# Altium schematic sheet top_level.SchDoc
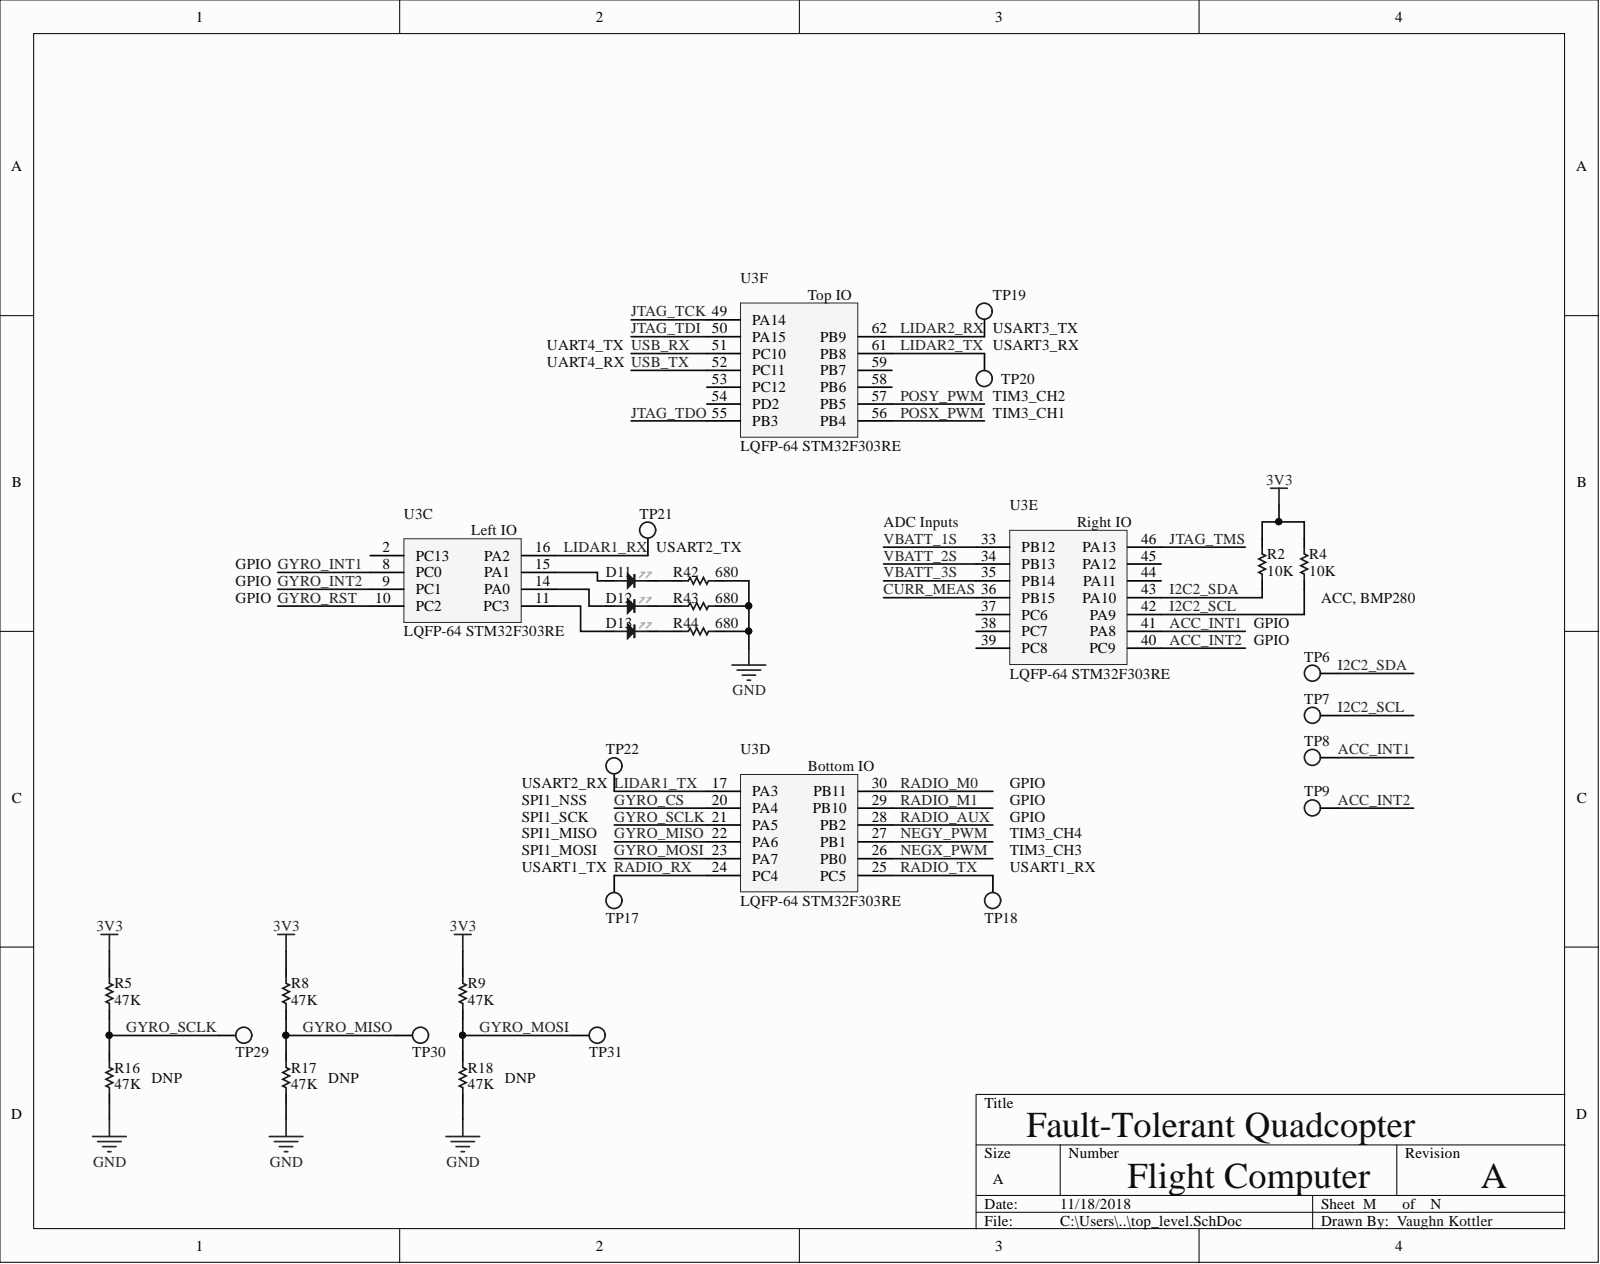
[diagram p0: top_level.SchDoc]

## Parts
D11  LED-WHT-20mA  [LED-0805]  (value not drawn)
D12  LED-WHT-20mA  [LED-0805]  (value not drawn)
D13  LED-WHT-20mA  [LED-0805]  (value not drawn)
R2  10K  [RES-0805]
R4  10K  [RES-0805]
R5  47K  [RES-0805]
R8  47K  [RES-0805]
R9  47K  [RES-0805]
R16  47K  [RES-0805]
R17  47K  [RES-0805]
R18  47K  [RES-0805]
R42  680  [RES-0805]
R43  680  [RES-0805]
R44  680  [RES-0805]
TP6  Test Point  [0603 Test Point]  (value not drawn)
TP7  Test Point  [0603 Test Point]  (value not drawn)
TP8  Test Point  [0603 Test Point]  (value not drawn)
TP9  Test Point  [0603 Test Point]  (value not drawn)
TP17  Test Point  [0603 Test Point]  (value not drawn)
TP18  Test Point  [0603 Test Point]  (value not drawn)
TP19  Test Point  [0603 Test Point]  (value not drawn)
TP20  Test Point  [0603 Test Point]  (value not drawn)
TP21  Test Point  [0603 Test Point]  (value not drawn)
TP22  Test Point  [0603 Test Point]  (value not drawn)
TP29  Test Point  [0603 Test Point]  (value not drawn)
TP30  Test Point  [0603 Test Point]  (value not drawn)
TP31  Test Point  [0603 Test Point]  (value not drawn)
U3  LQFP-64 STM32F303RE  [LQFP64]  (parts C,D,E,F)

## Nets
3V3: R2.2 R4.2 R5.2 R8.2 R9.2
GND: R16.1 R17.1 R18.1 R42.2 R43.2 R44.2
ACC_INT1: TP8.1 U3.41(PA8)
ACC_INT2: TP9.1 U3.40(PC9)
CURR_MEAS: U3.36(PB15)
GYRO_CS: U3.20(PA4)
GYRO_INT1: U3.8(PC0)
GYRO_INT2: U3.9(PC1)
GYRO_MISO: R8.1 R17.2 TP30.1 U3.22(PA6)
GYRO_MOSI: R9.1 R18.2 TP31.1 U3.23(PA7)
GYRO_RST: U3.10(PC2)
GYRO_SCLK: R5.1 R16.2 TP29.1 U3.21(PA5)
I2C2_SCL: R4.1 TP7.1 U3.42(PA9)
I2C2_SDA: R2.1 TP6.1 U3.43(PA10)
JTAG_TCK: U3.49(PA14)
JTAG_TDI: U3.50(PA15)
JTAG_TDO: U3.55(PB3)
JTAG_TMS: U3.46(PA13)
LIDAR1_RX: TP21.1 U3.16(PA2)
LIDAR1_TX: TP22.1 U3.17(PA3)
LIDAR2_RX: TP19.1 U3.62(PB9)
LIDAR2_TX: TP20.1 U3.61(PB8)
NEGX_PWM: U3.26(PB0)
NEGY_PWM: U3.27(PB1)
POSX_PWM: U3.56(PB4)
POSY_PWM: U3.57(PB5)
RADIO_AUX: U3.28(PB2)
RADIO_M0: U3.30(PB11)
RADIO_M1: U3.29(PB10)
RADIO_RX: TP17.1 U3.24(PC4)
RADIO_TX: TP18.1 U3.25(PC5)
USB_RX: U3.51(PC10)
USB_TX: U3.52(PC11)
VBATT_1S: U3.33(PB12)
VBATT_2S: U3.34(PB13)
VBATT_3S: U3.35(PB14)
NetD11_1: D11.1 U3.15(PA1)
NetD11_2: D11.2 R42.1
NetD12_1: D12.1 U3.14(PA0)
NetD12_2: D12.2 R43.1
NetD13_1: D13.1 U3.11(PC3)
NetD13_2: D13.2 R44.1

Not connected: U3 2(PC13) 37(PC6) 38(PC7) 39(PC8) 44(PA11) 45(PA12) 53(PC12) 54(PD2) 58(PB6) 59(PB7)

## Library data of the parts
D11-D13 = LED-WHT-20mA: 2.2V, 0805; Component Kind: Standard; ComponentLink1Description: Resistor Book (Amazon); ComponentLink1URL: https://www.amazon.com/gp/product/B071J21FYF/; Library Name: passive.SchLib; Supplier 1: Digi-Key; Supplier Part Number 1: 475-1410-1-ND
R2 R4 = RES-10K-1/8W: 0805; Component Kind: Standard; ComponentLink1Description: Resistor Book (Amazon); ComponentLink1URL: https://www.amazon.com/gp/product/B071J21FYF/; Library Name: passive.SchLib
R5 R8 R9 R16-R18 = RES-47K-1/8W: 0805; Component Kind: Standard; ComponentLink1Description: Resistor Book (Amazon); ComponentLink1URL: https://www.amazon.com/gp/product/B071J21FYF/; Library Name: passive.SchLib
R42-R44 = RES-680-1/8W: 0805; Component Kind: Standard; ComponentLink1Description: Resistor Book (Amazon); ComponentLink1URL: https://www.amazon.com/gp/product/B071J21FYF/; Library Name: passive.SchLib
TP6-TP9 TP17-TP22 TP29-TP31 = Test Point: 0603; Component Kind: Standard; Library Name: passive.SchLib; Supplier 1: Digi-Key; Supplier Part Number 1: A106145DKR-ND
U3 = STM32F303RET6: ARM Cortex-M4 32-bit MCU+FPU; Component Kind: Standard; ComponentLink1Description: NUCLEO-F303RE; ComponentLink1URL: https://www.st.com/content/st_com/en/products/evaluation-tools/product-evaluation-tools/mcu-eval-tools/stm32-mcu-eval-tools/stm32-mcu-nucleo/nucleo-f303re.html; ComponentLink2Description: MBED; ComponentLink2URL: https://os.mbed.com/platforms/ST-Nucleo-F303RE/; ComponentLink3Description: Datasheet; ComponentLink3URL: https://www.st.com/content/ccc/resource/technical/document/datasheet/2c/6f/d7/64/1f/a3/4f/c9/DM00118585.pdf/files/DM00118585.pdf/jcr:content/translations/en.DM00118585.pdf; ComponentLink4Description: Reference Manual; ComponentLink4URL: https://www.st.com/content/ccc/resource/technical/document/reference_manual/4a/19/6e/18/9d/92/43/32/DM00043574.pdf/files/DM00043574.pdf/jcr:content/translations/en.DM00043574.pdf; Library Name: ic.SchLib; Supplier 1: Digi-Key; Supplier Part Number 1: 497-15163-ND
